# Altium schematic sheet 04_USB_HOST.SchDoc
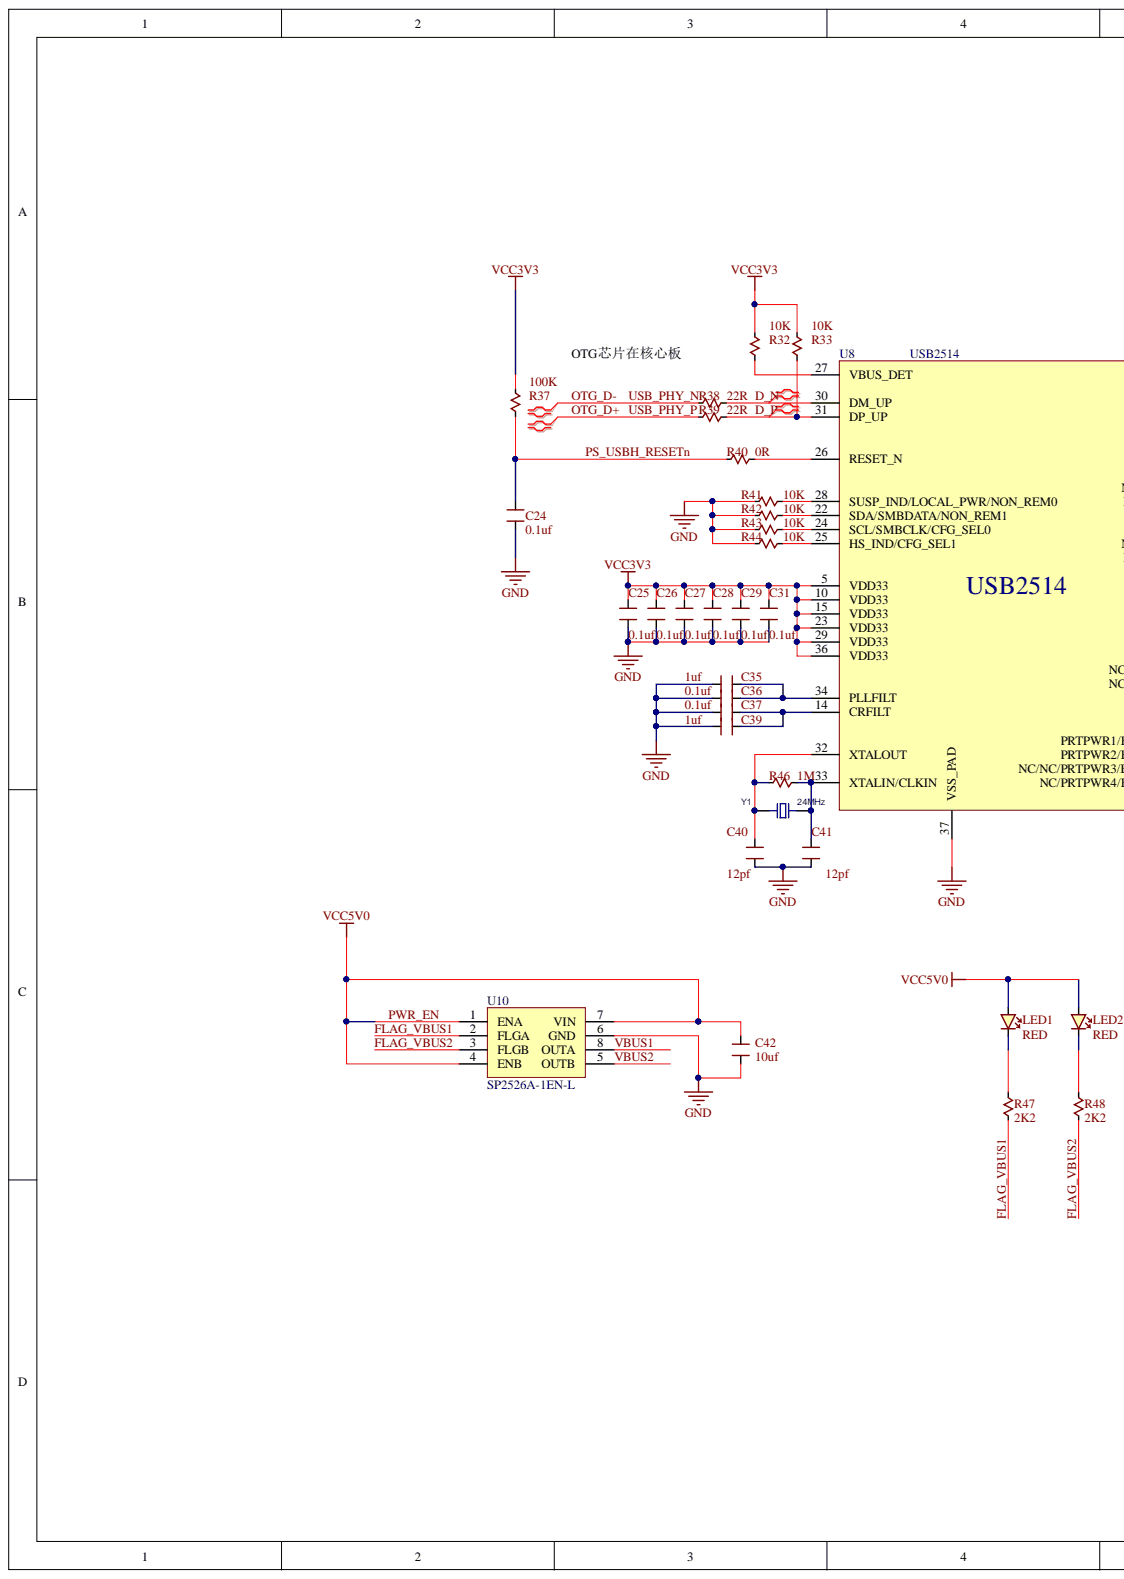
[diagram p0: 04_USB_HOST.SchDoc, left]
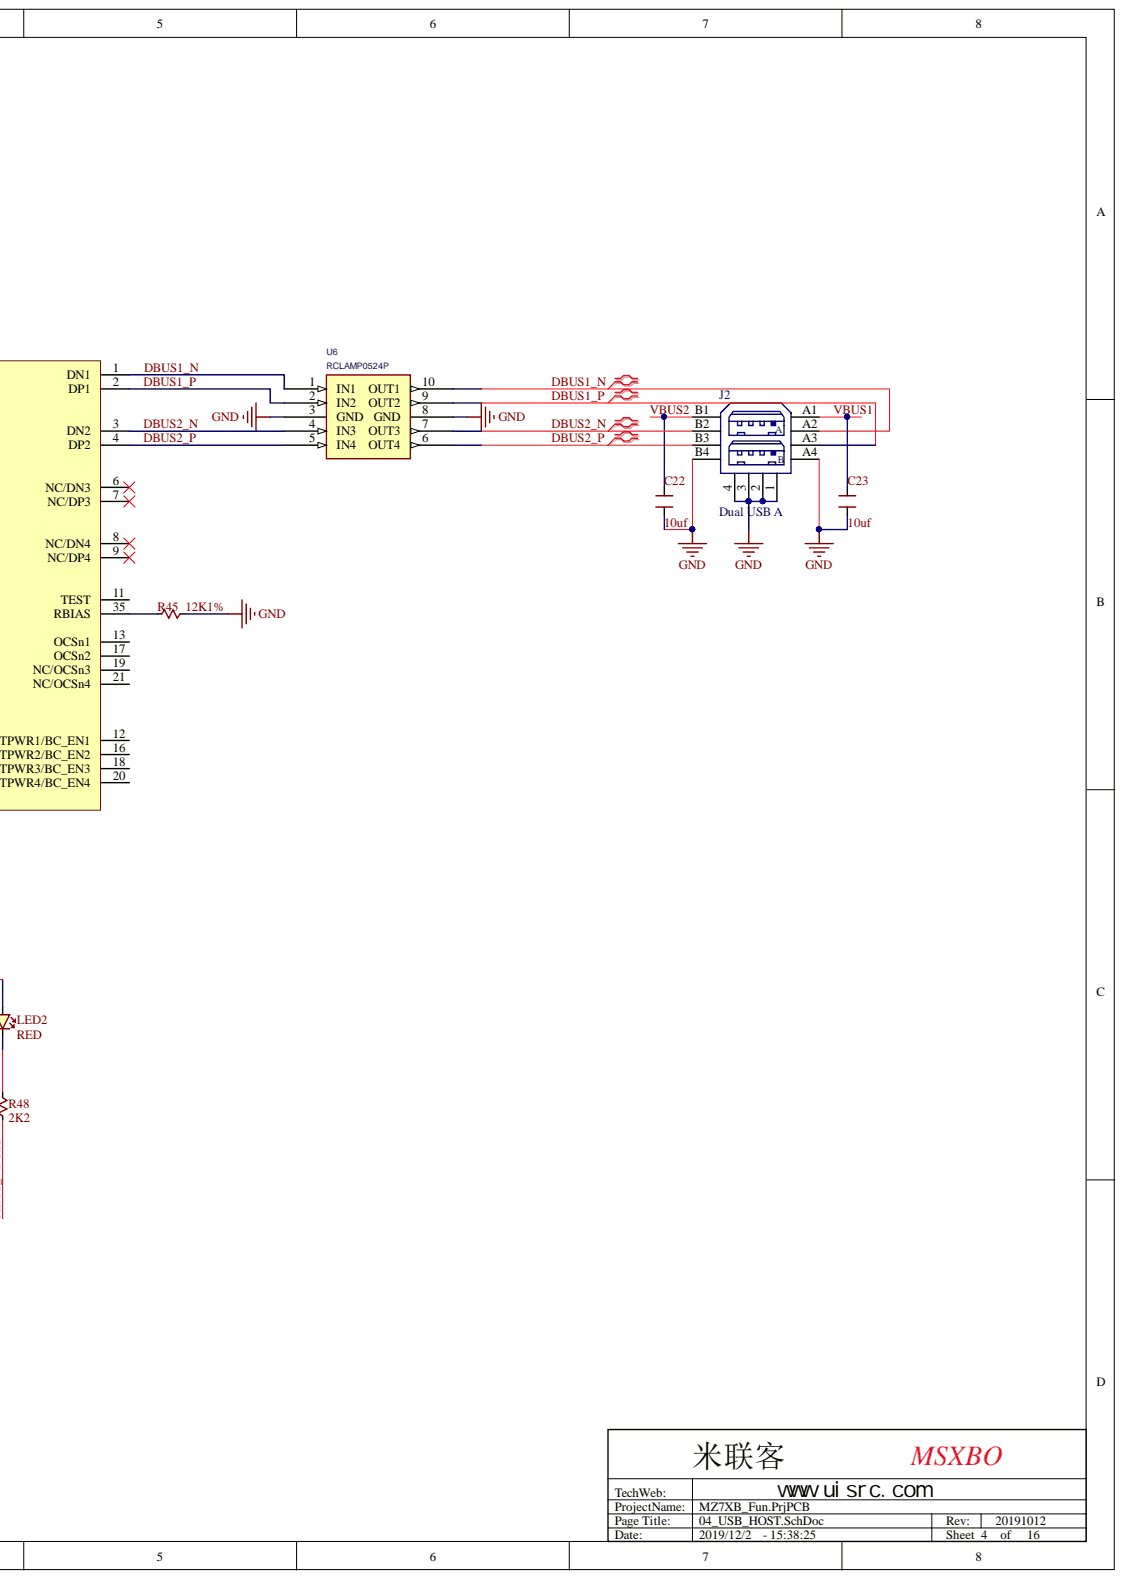
[diagram p1: 04_USB_HOST.SchDoc, right]

## Parts
C22  10uf  [CAP0603]
C23  10uf  [CAP0603]
C24  0.1uf  [CAP0402]
C25  0.1uf  [CAP0402]
C26  0.1uf  [CAP0402]
C27  0.1uf  [CAP0402]
C28  0.1uf  [CAP0402]
C29  0.1uf  [CAP0402]
C31  0.1uf  [CAP0402]
C35  1uf  [CAP0402]
C36  0.1uf  [CAP0402]
C37  0.1uf  [CAP0402]
C39  1uf  [CAP0402]
C40  12pf  [CAP0402]
C41  12pf  [CAP0402]
C42  10uf  [CAP0603]
J2  Dual USB A  [USBAX2]
LED1  LED  value RED  [D0603]
LED2  LED  value RED  [D0603]
R32  10K  [RES0402]
R33  10K  [RES0402]
R37  100K  [RES0402]
R38  22R  [RES0402]
R39  22R  [RES0402]
R40  0R  [RES0402]
R41  10K  [RES0402]
R42  10K  [RES0402]
R43  10K  [RES0402]
R44  10K  [RES0402]
R45  12K1%  [RES0402]
R46  1M  [RES0402]
R47  2K2  [RES0402]
R48  2K2  [RES0402]
U6  RCLAMP0524P  [SLP2510P8_10]
U8  USB2514  [QFN36]
U10  SP2526A-1EN-L  [SOP8]
Y1  24MHz  [CRY5032_2]

## Nets
GND: C22.1 C23.1 C24.2 C25.1 C26.1 C27.1 C28.1 C29.1 C31.1 C35.1 C36.2 C37.2 C39.2 C40.2 C41.2 C42.1 J2.1 J2.2 J2.3 J2.4 J2.A4(B) J2.B4 R41.1 R42.1 R43.1 R44.1 R45.2 U6.3(GND) U6.8(GND) U8.37(VSS_PAD) U10.6(GND)
VCC3V3: C25.2 C26.2 C27.2 C28.2 C29.2 C31.2 R32.1 R33.1 R37.1 U8.5(VDD33) U8.10(VDD33) U8.15(VDD33) U8.23(VDD33) U8.29(VDD33) U8.36(VDD33)
D_N: R38.2 U8.30(DM_UP)
D_P: R33.2 R39.2 U8.31(DP_UP)
DBUS1_N: J2.A2 U6.1(IN1) U6.10(OUT1) U8.1(DN1)
DBUS1_P: J2.A3 U6.2(IN2) U6.9(OUT2) U8.2(DP1)
DBUS2_N: J2.B2 U6.4(IN3) U6.7(OUT3) U8.3(DN2)
DBUS2_P: J2.B3 U6.5(IN4) U6.6(OUT4) U8.4(DP2)
FLAG_VBUS1: R47.1 U10.2(FLGA)
FLAG_VBUS2: R48.1 U10.3(FLGB)
OTG_D+: R39.1
OTG_D-: R38.1
PS_USBH_RESETN: C24.1 R37.2 R40.1
PWR_EN: C42.2 LED1.A LED2.A U10.1(ENA) U10.4(ENB) U10.7(VIN)
VBUS1: C23.2 J2.A1 U10.8(OUTA)
VBUS2: C22.2 J2.B1 U10.5(OUTB)
NetC35_2: C35.2 C36.1 U8.34(PLLFILT)
NetC37_1: C37.1 C39.1 U8.14(CRFILT)
NetC40_1: C40.1 R46.1 U8.32(XTALOUT) Y1.1
NetC41_1: C41.1 R46.2 U8.33(XTALIN/CLKIN) Y1.2
NetLED1_K: LED1.K R47.2
NetLED2_K: LED2.K R48.2
NetR32_2: R32.2 U8.27(VBUS_DET)
NetR40_2: R40.2 U8.26(RESET_N)
NetR41_2: R41.2 U8.28(SUSP_IND/LOCAL_PWR/NON_REM0)
NetR42_2: R42.2 U8.22(SDA/SMBDATA/NON_REM1)
NetR43_2: R43.2 U8.24(SCL/SMBCLK/CFG_SEL0)
NetR44_2: R44.2 U8.25(HS_IND/CFG_SEL1)
NetR45_1: R45.1 U8.35(RBIAS)

Not connected: U8 6(NC/DN3) 7(NC/DP3) 8(NC/DN4) 9(NC/DP4) 11(TEST) 12(PRTPWR1/BC_EN1) 13(OCSn1) 16(PRTPWR2/BC_EN2) 17(OCSn2) 18(NC/NC/PRTPWR3/BC_EN3) 19(NC/OCSn3) 20(NC/PRTPWR4/BC_EN4) 21(NC/OCSn4)

## Library data of the parts
C22-C29 C31 C35-C37 C39 C42 = CAPACITOR: Generic Capacitor; Value: 10nF; Component Kind: Standard; Library Name: MiSZ7020_core.IntLib
C40 C41 = CAPACITOR: Generic Capacitor; Value: 39pF; Component Kind: Standard; Library Name: Digilent.IntLib
LED1 LED2 = LED: Generic LED; Component Kind: Standard; Library Name: Digilent.IntLib
R32 R33 R37-R48 = RESISTOR: Generic Resistor; Value: 100; Component Kind: Standard; Library Name: MiSZ7020_core.IntLib
Y1 = CRYSTAL1: Component Kind: Standard
J2 = Dual USB A: Component Kind: Standard; Library Name: MIZ701_LIULE_LIB.IntLib
U6 = RCLAMP0524P: Component Kind: Standard
U8 = USB2514: Component Kind: Standard; Library Name: MIZ701_LIULE_LIB.IntLib
U10 = SP2526A-1EN-L: Component Kind: Standard; Library Name: MIZ701_LIULE_LIB.IntLib
